# Altium schematic sheet Sheet1.SchDoc
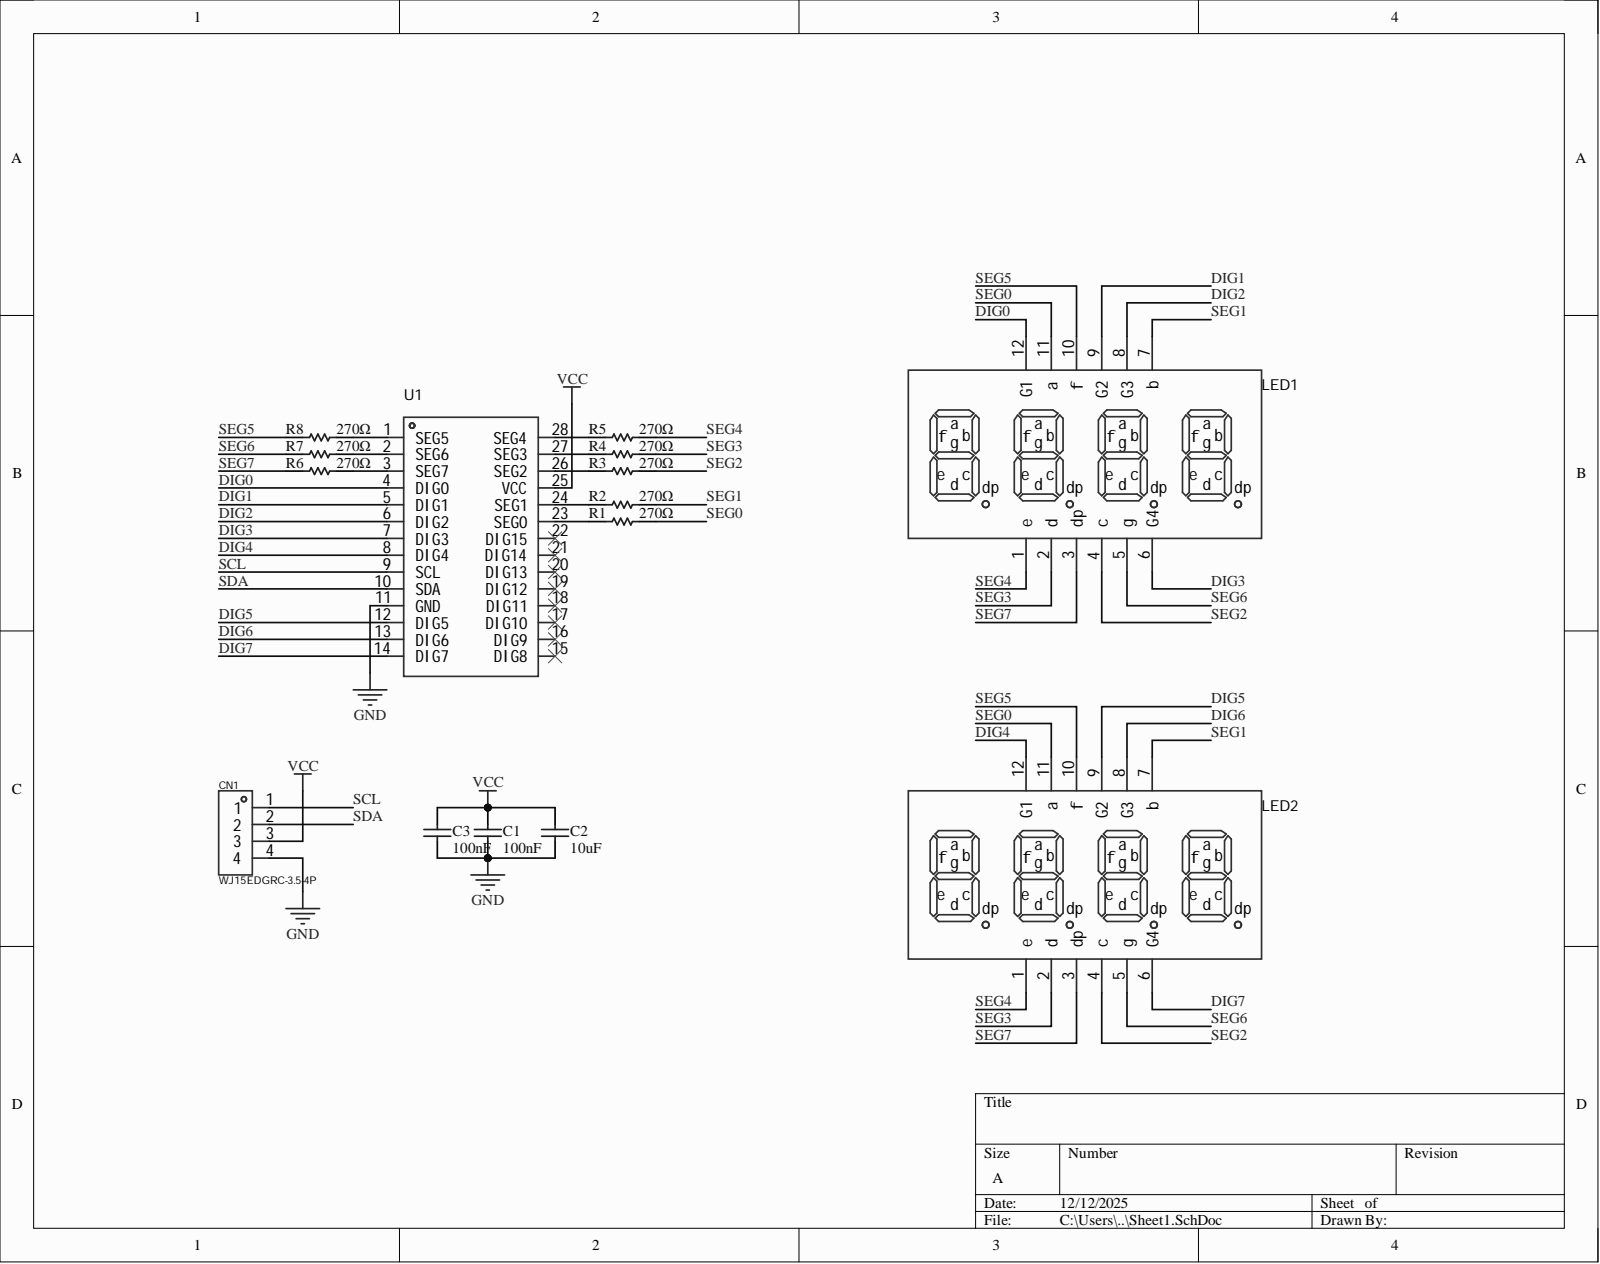
[diagram p0: Sheet1.SchDoc]

## Parts
C1  value 100nF  [C0603]  (hidden comment: Cap)
C2  value 10uF  [C0603]  (hidden comment: Cap)
C3  value 100nF  [C0603]  (hidden comment: Cap)
CN1  WJ15EDGRC-3.5-4P  [CONN-TH_4P-P3.50_WJ15EDGRC-3.5]
LED1  SR440801N/32  [LED-SEG-TH_12P-L71.6-W25.7-P2.54-S22.00-BL]  (value not drawn)
LED2  SR440801N/32  [LED-SEG-TH_12P-L71.6-W25.7-P2.54-S22.00-BL]  (value not drawn)
R1  value 270Ω  [R0603]  (hidden comment: Res1)
R2  value 270Ω  [R0603]  (hidden comment: Res1)
R3  value 270Ω  [R0603]  (hidden comment: Res1)
R4  value 270Ω  [R0603]  (hidden comment: Res1)
R5  value 270Ω  [R0603]  (hidden comment: Res1)
R6  value 270Ω  [R0603]  (hidden comment: Res1)
R7  value 270Ω  [R0603]  (hidden comment: Res1)
R8  value 270Ω  [R0603]  (hidden comment: Res1)
U1  CH453S  [SOP-28_L17.9-W7.5-P1.27-LS10.3-BL]  (value not drawn)

## Nets
GND: C1.1 C2.1 C3.1 CN1.4 U1.11(GND)
VCC: C1.2 C2.2 C3.2 CN1.3 U1.25(VCC)
DIG0: LED1.12(G1) U1.4(DIG0)
DIG1: LED1.9(G2) U1.5(DIG1)
DIG2: LED1.8(G3) U1.6(DIG2)
DIG3: LED1.6(G4) U1.7(DIG3)
DIG4: LED2.12(G1) U1.8(DIG4)
DIG5: LED2.9(G2) U1.12(DIG5)
DIG6: LED2.8(G3) U1.13(DIG6)
DIG7: LED2.6(G4) U1.14(DIG7)
SCL: CN1.1 U1.9(SCL)
SDA: CN1.2 U1.10(SDA)
SEG0: LED1.11(a) LED2.11(a) R1.1
SEG1: LED1.7(b) LED2.7(b) R2.1
SEG2: LED1.4(c) LED2.4(c) R3.1
SEG3: LED1.2(d) LED2.2(d) R4.1
SEG4: LED1.1(e) LED2.1(e) R5.1
SEG5: LED1.10(f) LED2.10(f) R8.2
SEG6: LED1.5(g) LED2.5(g) R7.2
SEG7: LED1.3(dp) LED2.3(dp) R6.2
NetR1_2: R1.2 U1.23(SEG0)
NetR2_2: R2.2 U1.24(SEG1)
NetR3_2: R3.2 U1.26(SEG2)
NetR4_2: R4.2 U1.27(SEG3)
NetR5_2: R5.2 U1.28(SEG4)
NetR6_1: R6.1 U1.3(SEG7)
NetR7_1: R7.1 U1.2(SEG6)
NetR8_1: R8.1 U1.1(SEG5)

Not connected: U1 15(DIG8) 16(DIG9) 17(DIG10) 18(DIG11) 19(DIG12) 20(DIG13) 21(DIG14) 22(DIG15)

## Library data of the parts
C1 C3 = Cap: Capacitor; Manufacturer: YAGEO; Mfr. Part #: CC0603KRX7R9BB104; C14663: 0603; Component Kind: Standard; LCSC Part #: C14663; Library Name: Miscellaneous Devices.IntLib; Signal Integrity: Cap; Simulation: CAP
C2 = Cap: Capacitor; Manufacturer: Samsung Electro-Mechanics; Mfr. Part #: CL10A106MA8NRNC; C14663: 0603; Component Kind: Standard; LCSC Part #: C96446; Library Name: Miscellaneous Devices.IntLib; Signal Integrity: Cap; Simulation: CAP
CN1 = WJ15EDGRC-3.5-4P: https://es.aliexpress.com/item/1005005511990041.html?spm=a2g0o.productlist.main.3.444dHbQMHbQMQW&algo_pvid=eebe03df-903d-4a01-8bcf-3ccdb62df07f&algo_exp_id=eebe03df-903d-4a01-8bcf-3ccdb62df07f-1&pdp_ext_f=%7B%22order%22%3A%221248%22%2C%22eval%22%3A%221%22%7D&pdp_npi=4%40dis%21EUR%212.01%211.89%21%21%212.04%211.92%21%402103890917374691080141047e4ab9%2112000033379333548%21sea%21DE%21709413394%21X&curPageLogUid=aJgEfep2J8j6&utparam-url=scene%3Asearch%7Cquery_from%3A; Manufacturer: DORABO; Mfr. Part #: DB2ERC-3.5-4P-GN; Value: 4P; Component Kind: Standard; LCSC Part #: C395831; Library Name: Schlib1.SchLib; Package: Push-Pull,P=3.5mm; PCB3D: CONN-TH_4P-P3.50_WJ15EDGRC-3.5
LED1 LED2 = SR440801N/32: Manufacturer: ARKLED(Wuxi ARK Tech Elec); Component Kind: Standard; JLCPCB Part #: C252230; Library Name: Schlib1.SchLib; MFR.Part #: SR440801N/32; PCB3D: LED-SEG-TH_12P-L71.6-W25.7-H8.5-P2.54-S22.0
R1-R8 = Res1: Resistor; Manufacturer: UNI-ROYAL(Uniroyal Elec); Mfr. Part #: 0603WAF2700T5E; Component Kind: Standard; LCSC Part #: C22966; Library Name: Miscellaneous Devices.IntLib; Package: 0603; Signal Integrity: Res; Simulation: RESISTOR
U1 = CH453S: Manufacturer: WCH(Jiangsu Qin Heng); Value: 16 Digit; Component Kind: Standard; JLCPCB Part #: C111672; Library Name: Schlib1.SchLib; MFR.Part #: CH453S; Package: SOP-28-300mil; PCB3D: SOP-28_L17.9-W7.5-P1.27-LS10.3-BL
